# Altium schematic sheet Face PCB Micro & Status LEDs.SchDoc
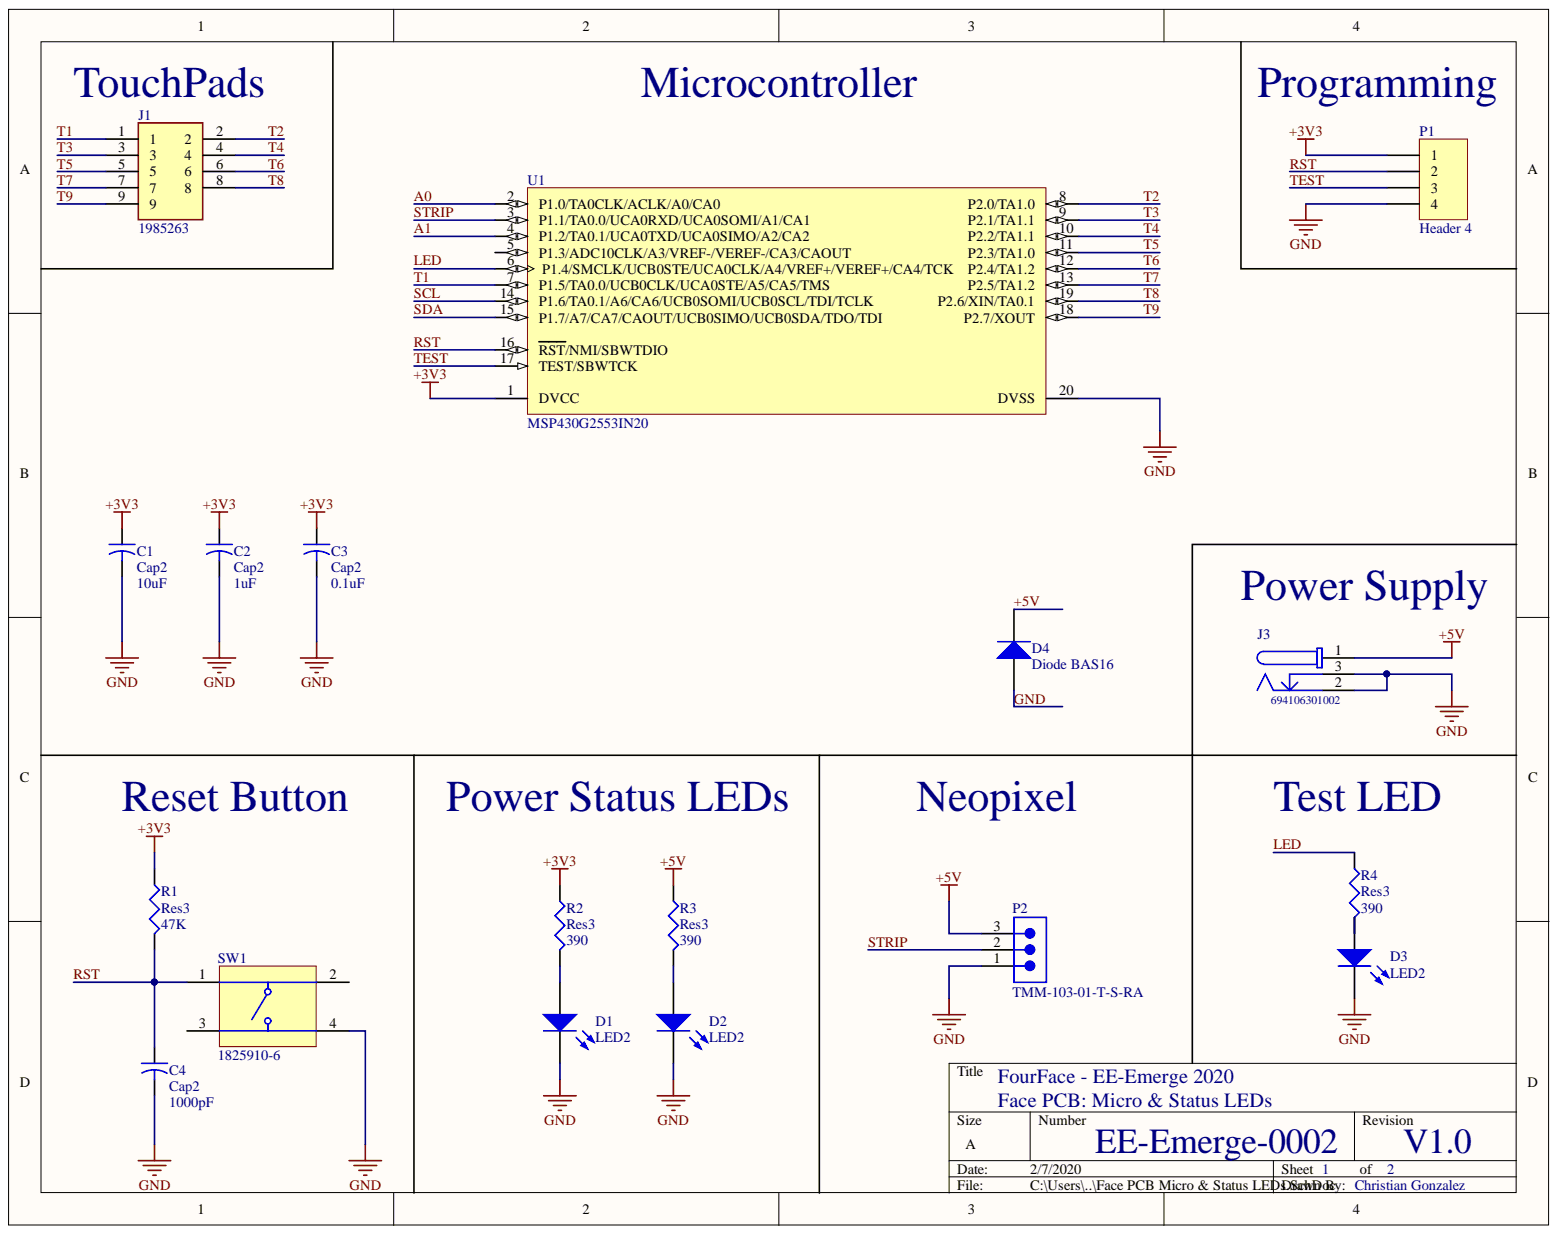
[diagram p0: Face PCB Micro & Status LEDs.SchDoc]

## Parts
C1  Cap2  value 10uF  [C0805]
C2  Cap2  value 1uF  [C0805]
C3  Cap2  value 0.1uF  [C0805]
C4  Cap2  value 1000pF  [C0805]
D1  LED2  [3.2X1.6X1.1]
D2  LED2  [3.2X1.6X1.1]
D3  LED2  [3.2X1.6X1.1]
D4  Diode BAS16  [SOT-23_N]
J1  1985263  [1985263]
J3  694106301002  [694106301002]
P1  Header 4  [HDR1X4]
P2  TMM-103-01-T-S-RA  [SMTC-TMM-103-01-X-S-RA]
R1  Res3  value 47K  [6-0805_N]
R2  Res3  value 390  [6-0805_N]
R3  Res3  value 390  [6-0805_N]
R4  Res3  value 390  [6-0805_N]
SW1  1825910-6  [TECO-1825910-6_V]
U1  MSP430G2553IN20  [N0020A]

## Nets
+3V3: C1.1 C2.1 C3.1 P1.1 R1.1 R2.1 U1.1(DVCC)
+5V: D4.3 J3.1 P2.3 R3.1
A0: U1.2(P1.0/TA0CLK/ACLK/A0/CA0)
A1: U1.4(P1.2/TA0.1/UCA0TXD/UCA0SIMO/A2/CA2)
GND: C1.2 C2.2 C3.2 C4.2 D1.2 D2.2 D3.2 D4.1 J3.2 J3.3 P1.4 P2.1 SW1.4 U1.20(DVSS)
LED: R4.1 U1.6(P1.4/SMCLK/UCB0STE/UCA0CLK/A4/VREF+/VEREF+/CA4/TCK)
RST: C4.1 P1.2 R1.2 SW1.1 U1.16(RST/NMI/SBWTDIO)
SCL: U1.14(P1.6/TA0.1/A6/CA6/UCB0SOMI/UCB0SCL/TDI/TCLK)
SDA: U1.15(P1.7/A7/CA7/CAOUT/UCB0SIMO/UCB0SDA/TDO/TDI)
STRIP: P2.2 U1.3(P1.1/TA0.0/UCA0RXD/UCA0SOMI/A1/CA1)
T1: J1.1 U1.7(P1.5/TA0.0/UCB0CLK/UCA0STE/A5/CA5/TMS)
T2: J1.2 U1.8(P2.0/TA1.0)
T3: J1.3 U1.9(P2.1/TA1.1)
T4: J1.4 U1.10(P2.2/TA1.1)
T5: J1.5 U1.11(P2.3/TA1.0)
T6: J1.6 U1.12(P2.4/TA1.2)
T7: J1.7 U1.13(P2.5/TA1.2)
T8: J1.8 U1.19(P2.6/XIN/TA0.1)
T9: J1.9 U1.18(P2.7/XOUT)
TEST: P1.3 U1.17(TEST/SBWTCK)
NetD1_1: D1.1 R2.2
NetD2_1: D2.1 R3.2
NetD3_1: D3.1 R4.2

Not connected: SW1 2 3; U1 5(P1.3/ADC10CLK/A3/VREF-/VEREF-/CA3/CAOUT)

## Library data of the parts
C1 C3 C4 = Cap2: Capacitor; Component Kind: Standard; PackageDescription: Capacitor; 2 Leads; PackageReference: CAPR5-4X5; Published: 23-Sep-2002; Signal Integrity: Cap; Simulation: CAP; Library Name: EE_Emerge_SkillsProject.SCHLIB; Publisher: Altium Limited
C2 = Cap2_4: Capacitor; Component Kind: Standard; PackageDescription: Capacitor; 2 Leads; PackageReference: CAPR5-4X5; Published: 23-Sep-2002; Signal Integrity: Cap; Simulation: CAP; Library Name: EE_Emerge_SkillsProject.SCHLIB; Publisher: Altium Limited
D1-D3 = LED2: Typical RED, GREEN, YELLOW, AMBER GaAs LED; Component Kind: Standard; LatestRevisionDate: 17-Jul-2002; LatestRevisionNote: Re-released for DXP Platform.; Library Name: PCB_Project_2.IntLib; PackageDescription: SM LED; 2 Flat Leads; PackageReference: 3.2X1.6X1.1; PackageVersion: Feb-2002; Published: 8-Jun-2000; Simulation: LED2; Publisher: Altium Limited
D4 = Diode BAS16: Silicon Switching Diode for High-Speed Switching; Note: Pin 2 is not connected and not drawn.; Component Kind: Standard; ComponentLink1Description: Manufacturer Link; ComponentLink1URL: http://www.infineon.com/; ComponentLink2Description: Datasheet; ComponentLink2URL: http://www.infineon.com/upload/Document/cmc_upload/documents/012/5331/bas16series.pdf; DatasheetVersion: Oct-1999; LatestRevisionDate: 29-May-2009; LatestRevisionNote: IPC-7351 Footprint Added.; Library Name: Miscellaneous Devices.IntLib; PackageDescription: 3-Pin SOT-23 Package 0.95 mm Pitch, 2.5 mm Lead Span; PackageReference: SOT-23; PackageVersion: Sep-2001; Published: 21-Mar-2003; Simulation: BAS16; Publisher: Altium Limited
J1 = 1985263: Connector; Manufacturer_Name: Phoenix Contact; Allied_Number: 70055076; Allied Price/Stock: https://www.alliedelec.com/phoenix-contact-1985263/70055076/; Component Kind: Standard; Datasheet Link: https://www.phoenixcontact.com/online/portal/us?uri=pxc-oc-itemdetail:pid=1985263&library=usen&tab=1; Height: 13mm; Manufacturer_Part_Number: 1985263; Mouser Part Number: 651-1985263; Mouser Price/Stock: https://www.mouser.com/Search/Refine.aspx?Keyword=651-1985263; RS Part Number: 6482559; RS Price/Stock: http://uk.rs-online.com/web/p/products/6482559
J3 = CMP-1502-00188-1: DC Power Jack Connector WR-DC, Male, Right Angled, THT, 5 A, 24 V; Manufacturer: Wurth Elektronik; Opening Diameter: 6.4mm; Plug Inner Diameter: 2.05mm; Plug Outer Diameter: 5.5mm; Rated Current: 5A; Working Voltage: 24V; Component Kind: Standard; Datasheet URL: http://katalog.we-online.de/em/datasheet/6941xx301002.pdf; Gender: Male; Library Name: Altium Content Vault; Manufacturer URL: http://www.we-online.de; Mounting Technology: THT; Operating Temperature: -40to85degC; Orientation: RightAngled; Package Reference: 694106301002; Packaging: Bag; Part Number: 694106301002; Publisher: WurthElektronik
P1 = Header 4: Header, 4-Pin; Component Kind: Standard; LatestRevisionDate: 17-Jul-2002; LatestRevisionNote: Re-released for DXP Platform.; Library Name: Miscellaneous Connectors.IntLib; Signal Integrity: Connector; Publisher: Altium Limited
P2 = CMP-1029-00176-1: Low Profile Through-Hole Header, Right Angle, -55 to 105 degC, 2 mm Pitch, 3-Pin, Male, RoHS; Manufacturer: Samtec; Pitch: 2 mm; Component Kind: Standard; ComponentLink1Description: Manufacturer URL; ComponentLink1URL: http://www.samtec.com; ComponentLink2Description: Datasheet; ComponentLink2URL: http://d2a072wq521u33.cloudfront.net/catalog_english//TMM_TH.PDF; ComponentLink3Description: Package Specification; ComponentLink3URL: http://www.samtec.com/documents/webfiles/cpdf/TMM-1XX-XX-XX-X-RA-XXX-MKT.pdf; DatasheetVersion: F-214; Library Name: PCB_Project_2.IntLib; Manufacturer 1: Samtec; Manufacturer Part Number 1: TMM-103-01-T-S-RA; Mounting Technology: Through-hole; Orientation: Right Angle; PackageDescription: 2 mm Terminal Strip Assembly (R/A); PackageReference: TMM-103-01-X-S-RA; PackageVersion: V, 3/2013; PartNumber: TMM-103-01-T-S-RA; RoHS: True; Temperature Range: -55 to 105 degC
R1-R4 = Res3: Resistor; Component Kind: Standard; LatestRevisionDate: 29-May-2009; LatestRevisionNote: IPC-7351 Footprint Added.; PackageDescription: Chip Resistor; PackageReference: J1-0603; Published: 8-Jun-2000; Signal Integrity: Res; Simulation: RESISTOR; Library Name: EE_Emerge_SkillsProject.SCHLIB; Publisher: Altium Limited
SW1 = CMP-1684-00021-1: Tact Switch, SPST-NO, 0.05 A, -35 to 85 degC, 4-Pin THD, RoHS, Bulk; Manufacturer: TE Connectivity; Actuator Color: Black; Actuator Length: 1.4mm; Actuator Material: PolybutyleneTerephthalate; Actuator Style: RoundButton; Body Orientation: Straight; Bushing Type: Unthreaded; Contact Rating @ Voltage: 0.05A@24VDC; Dielectric Strength: 500VAC; Electrical Life: 100000Cycles; Function: MomentaryContact; Illumination: N/R; Maximum Contact Resistance: 100mOhm; Maximum DC Voltage Rating: 24V; Pole Throw Configuration: SPST; Switch Configuration: OFF(ON); Base Material: N/A; Component Kind: Standard; Contact Material: CopperAlloy; Contact Plating: Silver; Datasheet URL: http://www.te.com/commerce/DocumentDelivery/DDEController?Action=showdoc&DocId=Customer+Drawing%7F1825910%7FC9%7Fpdf%7FEnglish%7FENG_CD_1825910_C9.pdf%7F1825910-6; Datasheet Version: Rev. C9; Dimension: 5.99x5mm; Family: 1825910; Housing Material: N/A; Library Name: Altium Content Vault; Manufacturer URL: http://www.te.com; Maximum AC Voltage Rating: N/A; Mechanical Life: 100000Cycles; Minimum Insulation Resistance: 100MOhm; Mounting: ThroughHole; Operating Temperature: -35to85C; Package Description: 4-Pin Through Hole Device, Body 5.99 x 5.99 mm, Pitch 6.5 mm; Package Reference: THD; Packaging: N/A; Part No: 1825910-6; Product Weight: N/A; RoHS: Yes; Seal Description: N/A; Seal Location: N/A; Terminal Type: PCPins; Thread Size: N/R
U1 = CMP-0365-00184-2: 16 MHz Mixed Signal Microcontroller with 16 KB Flash, 512 B SRAM and 24 GPIOs, -40 to 85 degC, 20-pin DIP (N), Pb-Free (RoHS); Manufacturer: Texas Instruments; ADC: 10-bit SAR; ADC Channels: 8; Brown Out Reset: Yes; Flash(KB): 16; GPIO: 24; SRAM(B): 512; SubFamily: Value Line; Timers - 16-bit: 2; USCI_A (UART/LIN/IrDA/SPI): 1; Cap touch I/O: Yes; Code_JEDEC: MS-001; Comparators: Yes; Component Kind: Standard; ComponentLink1Description: Manufacturer URL; ComponentLink1URL: http://www.ti.com/; ComponentLink2Description: Datasheet; ComponentLink2URL: http://www.ti.com/general/docs/lit/getliterature.tsp?genericPartNumber=MSP430G2553&fileType=pdf; ComponentLink3Description: Package Specification; ComponentLink3URL: http://www.ti.com/litv/pdf/mpds177g; DatasheetVersion: SLAS735G; Eco-Plan: Pb-Free (RoHS); Frequency(MHz): 16; Library Name: PCB_Project_2.IntLib; Manufacturer 1: Texas Instruments; Manufacturer Part Number 1: MSP430G2553IN20; Mounting Technology: Through Hole; PackageDescription: 20-Pin Plastic In-Line, Body 25.40 x 6.35 mm, Pitch 2.54 mm; PackageReference: N0020A; PackageVersion: 4040049/E; PartNumber: MSP430G2553IN20; Temp Sensor: Yes; USCI_B (I2C & SPI): 1; Watchdog: Yes
